# Altium schematic sheet DriverCCT.SchDoc(DriverCCT)
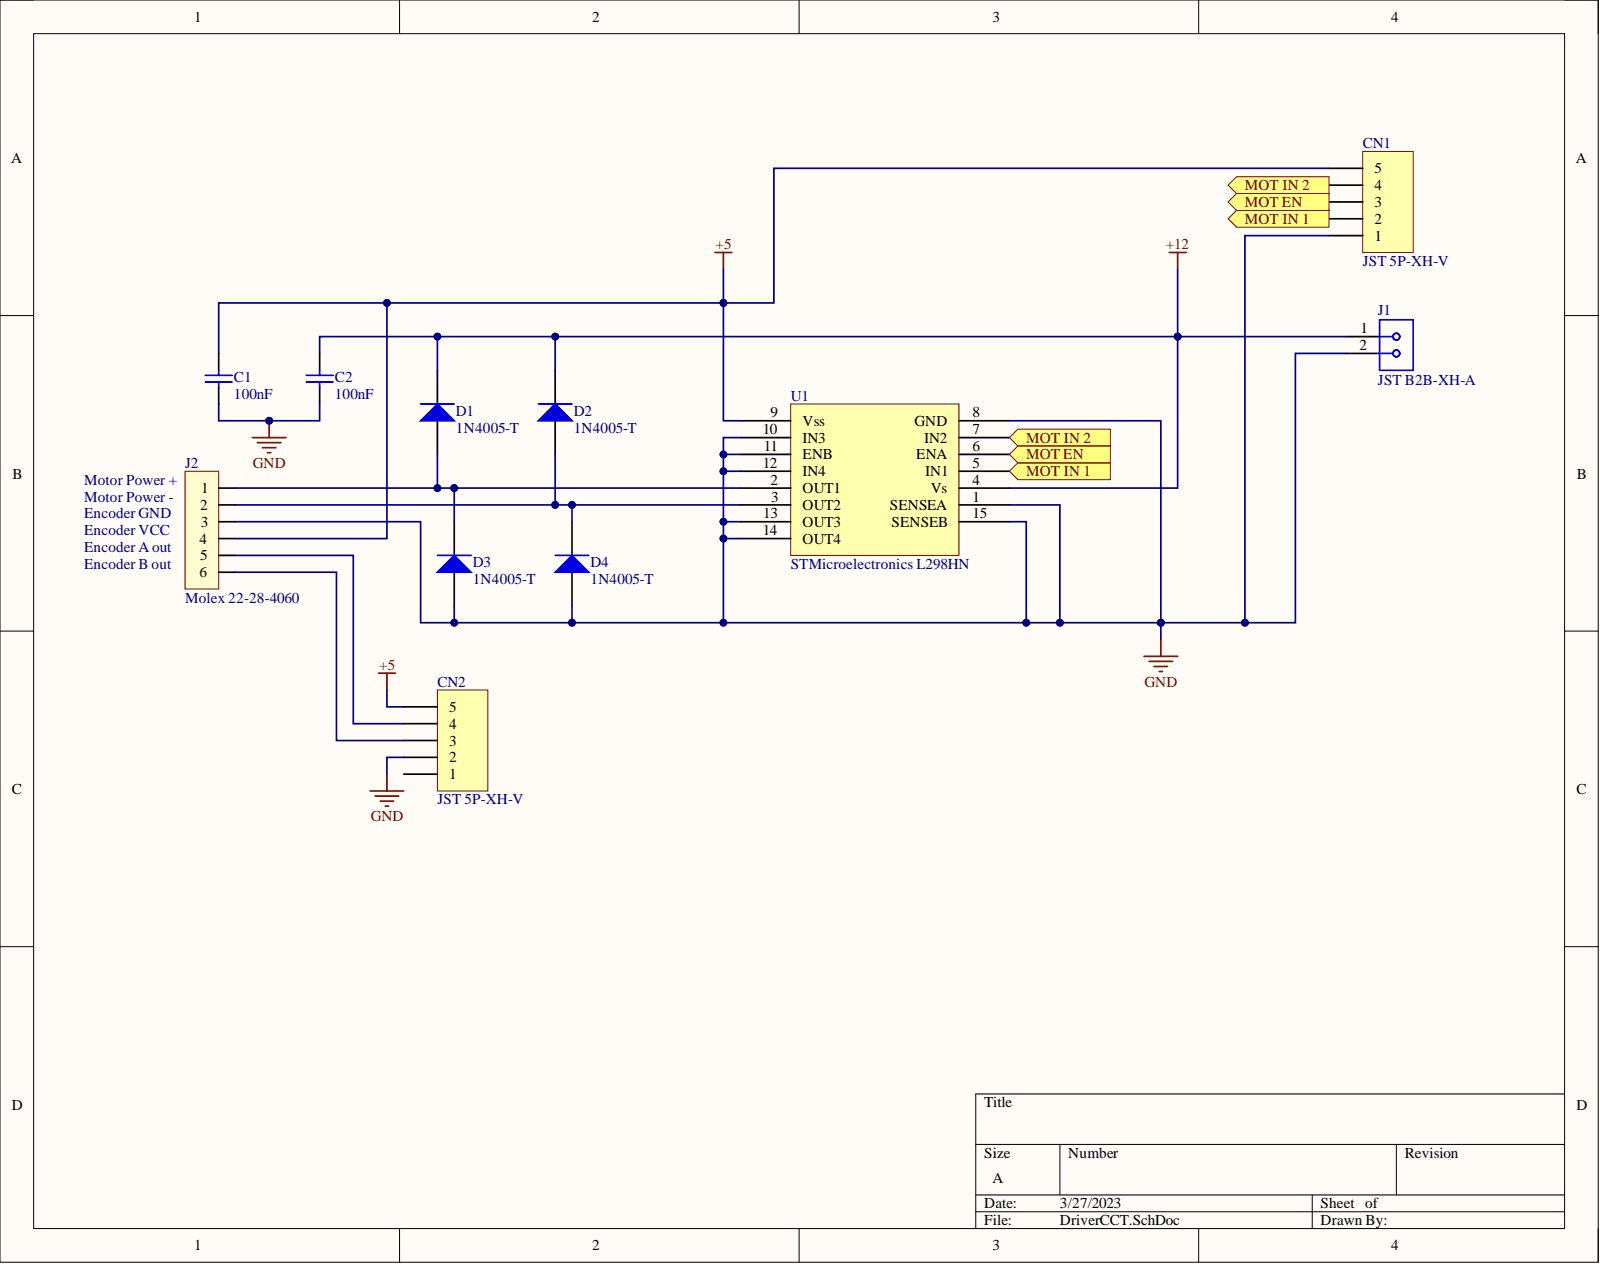
[diagram p0: DriverCCT.SchDoc]

## Parts
C1  100nF
C2  100nF
CN1  JST 5P-XH-V  [PCB-mfi6vvq65x2nl6te1krx-1]
CN2  JST 5P-XH-V  [PCB-mfi6vvq65x2nl6te1krx-1]
D1  1N4005-T
D2  1N4005-T
D3  1N4005-T
D4  1N4005-T
J1  JST B2B-XH-A  Manufacturer Part Number: B2B-XH-A  [PCB-pp1at3pfx7vls1ur72ey-1]
J2  Molex 22-28-4060  Manufacturer: Molex  Manufacturer Part Number: 22-28-4060  [PCB-1127631-8]
U1  STMicroelectronics L298HN

## Ports
MOT EN, MOT IN 1, MOT IN 2

## Nets
+5: C1.2 CN1.5 CN2.5 J2.4 U1.9(Vss)
+12: C2.2 D1.2 D2.2 J1.1 U1.4(Vs)
GND: C1.1 C2.1 CN1.1 CN2.2 D3.1 D4.1 J1.2 J2.3 U1.1(SENSEA) U1.8(GND) U1.10(IN3) U1.11(ENB) U1.12(IN4) U1.13(OUT3) U1.14(OUT4) U1.15(SENSEB)
MOT EN: CN1.3 U1.6(ENA) ; port MOT EN
MOT IN 1: CN1.2 U1.5(IN1) ; port MOT IN 1
MOT IN 2: CN1.4 U1.7(IN2) ; port MOT IN 2
NetCN2_3: CN2.3 J2.6
NetCN2_4: CN2.4 J2.5
NetD1_1: D1.1 D3.2 J2.1 U1.2(OUT1)
NetD2_1: D2.1 D4.2 J2.2 U1.3(OUT2)

Not connected: CN2 1

## Library data of the parts
C1 C2 = CMP-128540-5: CAP CER 0.1UF 50V 10% RADIAL; Manufacturer Part Number: K104K10X7RF5UH5; Capacitance: 0.1u; Dielectric Characteristic: X7R; Voltage Rating (DC): 50.0 V; Capacitance Tolerance: ±10%; Component Kind: Standard; Library Name: My Personal Space; Octopart Url: https://octopart.com/k104k10x7rf5uh5-vishay-44036914; OctopartID: 39a8f23deffce66f; Size-Diameter: 0.0005 m; Size-Height: 0.0036 m; Size-Width: 0.0036 m
CN1 CN2 = CMP-2481793-9: Connector Header, XH Series, 5 Position, 2.50mm, Through Hole, Press-Fit, Solder, Natural; Manufacturer Part Number: B5B-XH-A(LF)(SN); Contact Resistance: 10.0 mΩ; Current Rating: 3.00 A; Gender: Male; Insulation Resistance: 1.00 GΩ; Lead-Free Status: Lead Free; Number of Contacts: 5; Voltage Rating (AC): 250 V; Component Kind: Standard; Contact Material: Brass; Contact Plating: Tin, Tin Lead; Library Name: My Personal Space; Mounting Style: Through Hole, Vertical; Octopart URL: https://octopart.com/b5b-xh-a%28lf%29%28sn%29-jst-3990208; OctopartID: 8031490747975ff6; Operating Temperature: -25.0 °C (min); Packaging: Box; REACH SVHC Compliance: No SVHC; RoHS: Compliant
D1-D4 = CMP-815221-9: 1.0 A Rectifier, 8 pF, 600 V, -65 to 150 degC, 2-Pin DO-41 Plastic, RoHS, Tape and Reel; Capacitance: 8e-12 F; Current Rating: 1.0 A; Lead-Free Status: Lead Free; Polarity: Standard; Voltage Rating (DC): 600.0 V; Case/Package: DO-41; Component Kind: Standard; Library Name: My Personal Space; Lifecycle Status: Active; Manufacturer Part Number: 1N4005-T; Mounting Style: Through Hole; Octopart Url: https://octopart.com/1n4005-t-diodes+inc.-55426531; OctopartID: 79b4b3f3e131a673; RoHS: Compliant
J1 = CMP-1d67d451da9ec2a0-5: Conn Shrouded Header HDR 2 POS 2.5mm Solder ST Thru-Hole Box; Component Kind: Standard; Contact Material: Phosphor Bronze; Library Name: My Personal Space; Octopart URL: https://octopart.com/b2b-xh-a-jst-3990175; OctopartID: 1d67d451da9ec2a0; Orientation: Straight
J2 = CMP-b7717ee446b868b6-8: KK® 254 Breakaway Header, Vertical, 6 Circuits, Tin (Sn) Plating, Mating Pin Length 6.09mm; Current Rating: 4.00 A; Gender: Male; Glow Wire Compliant: Non-Compliant; Lead-Free Status: Lead Free; Number of Circuits: 5, 6; Number of Contacts: 6; Pin Pitch: 2.54 mm; Voltage Rating (DC): 250 V; Color: Black; Component Kind: Standard; Contact Material: Phosphor Bronze; Contact Plating: Tin; Flammability Rating: UL 94 V-0; Halogen Free Status: Not Low Halogen; Housing Color: Black; Housing Material: Nylon; Library Name: My Personal Space; Lifecycle Status: Active; Mounting Style: Through Hole; Number of Positions: 6; Number of Rows: 1; Octopart URL: https://octopart.com/22-28-4060-molex-278174; OctopartID: f6d33fdded2b2914; Operating Temperature: 75.0 °C (max); Orientation: Vertical; Packaging: Bulk; RoHS: Compliant; Size-Height: 2.29 mm; Size-Length: 15.2 mm; Size-Width: 2.49 mm
U1 = CMP-7f8fb6854c1aa048-2: PMIC - gate/half-bridge gate drivers STMicroelectronics L298HN Inductive Bipolar Multiwatt 15; Component Kind: Standard; Library Name: My Personal Space
